# Altium schematic sheet STM32.SchDoc
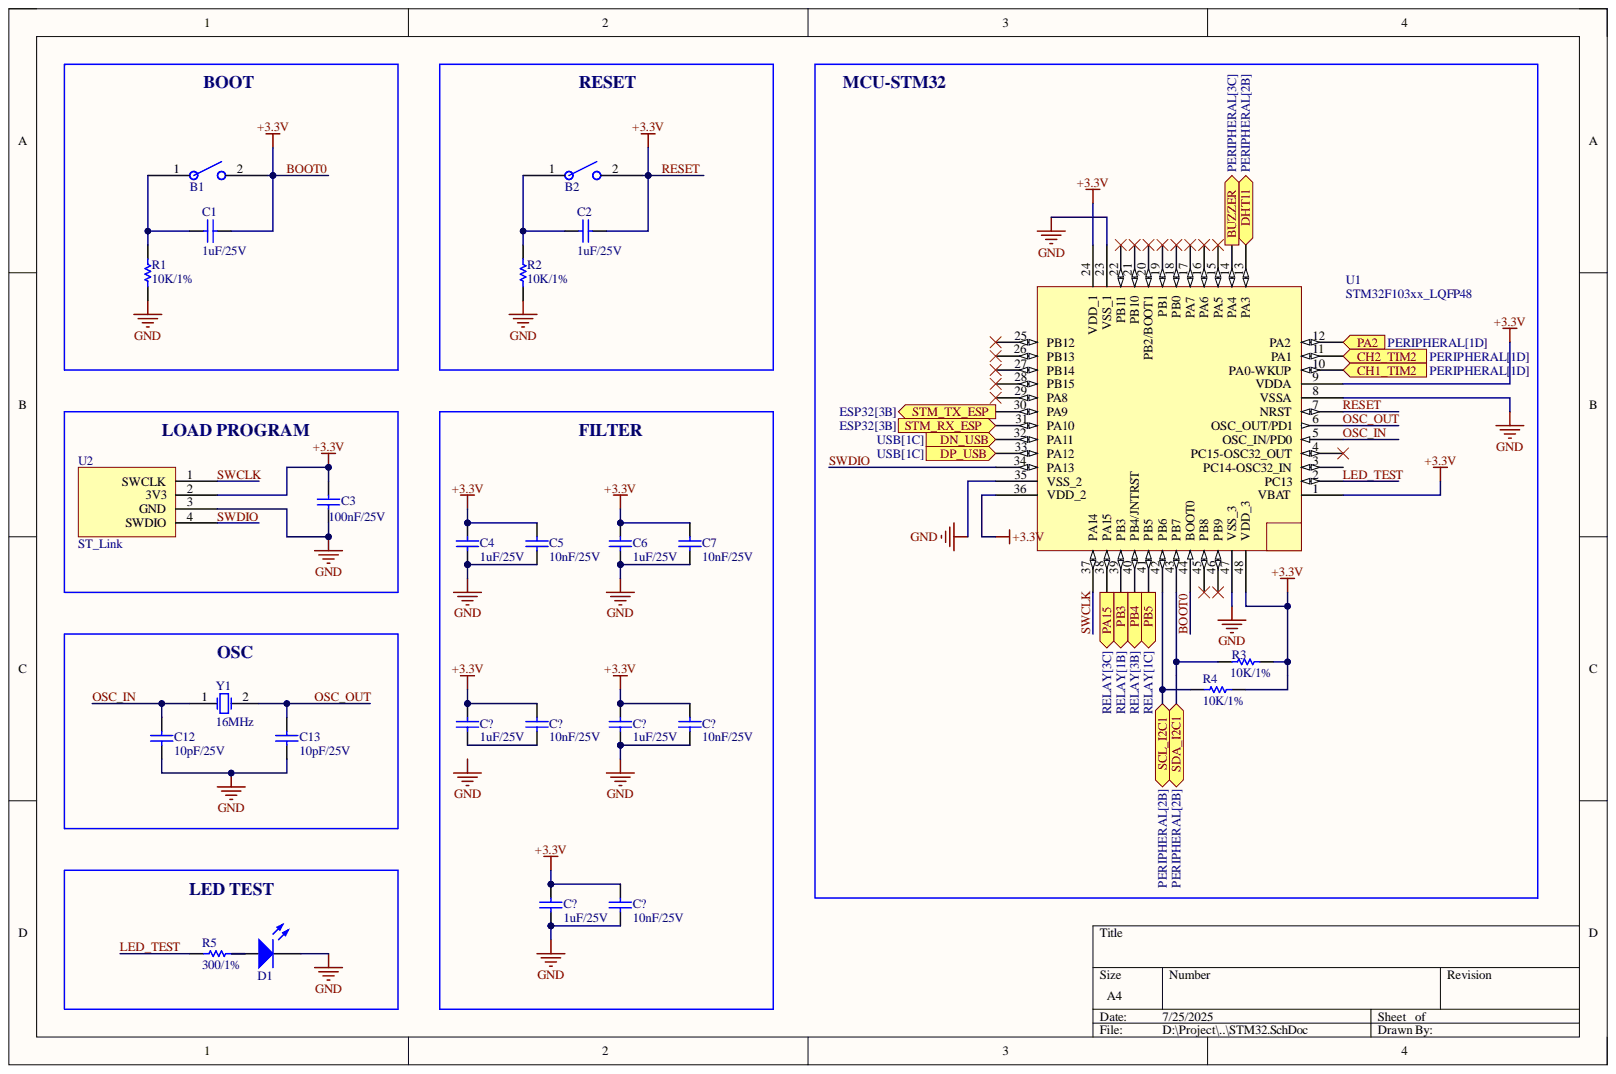
[diagram p0: STM32.SchDoc]

## Parts
B1  Button SMD 2 Pin 3x4mm  [BUTTON SMD 2 PIN]  (value not drawn)
B2  Button SMD 2 Pin 3x4mm  [BUTTON SMD 2 PIN]  (value not drawn)
C1  value 1uF/25V  [CAP-SM-0805]  (hidden comment: Cap Non-Pol SMD)
C2  value 1uF/25V  [CAP-SM-0805]  (hidden comment: Cap Non-Pol SMD)
C3  value 100nF/25V  [CAP-SM-0805]  (hidden comment: Cap Non-Pol SMD)
C4  value 1uF/25V  [CAP-SM-0805]  (hidden comment: Cap Non-Pol SMD)
C5  value 10nF/25V  [CAP-SM-0805]  (hidden comment: Cap Non-Pol SMD)
C6  value 1uF/25V  [CAP-SM-0805]  (hidden comment: Cap Non-Pol SMD)
C7  value 10nF/25V  [CAP-SM-0805]  (hidden comment: Cap Non-Pol SMD)
C12  value 10pF/25V  [CAP-SM-0805]  (hidden comment: Cap Non-Pol SMD)
C13  value 10pF/25V  [CAP-SM-0805]  (hidden comment: Cap Non-Pol SMD)
C?  value 10nF/25V  [CAP-SM-0805]  (hidden comment: Cap Non-Pol SMD)
C?  value 1uF/25V  [CAP-SM-0805]  (hidden comment: Cap Non-Pol SMD)
C?  value 10nF/25V  [CAP-SM-0805]  (hidden comment: Cap Non-Pol SMD)
C?  value 10nF/25V  [CAP-SM-0805]  (hidden comment: Cap Non-Pol SMD)
C?  value 1uF/25V  [CAP-SM-0805]  (hidden comment: Cap Non-Pol SMD)
C?  value 1uF/25V  [CAP-SM-0805]  (hidden comment: Cap Non-Pol SMD)
D1  LED SMD  [LED_0603_Blue]  (value not drawn)
R1  value 10K/1%  [RES-SM-0805]  (hidden comment: RESISTOR SMD)
R2  value 10K/1%  [RES-SM-0805]  (hidden comment: RESISTOR SMD)
R3  value 10K/1%  [RES-SM-0805]  (hidden comment: RESISTOR SMD)
R4  value 10K/1%  [RES-SM-0805]  (hidden comment: RESISTOR SMD)
R5  value 300/1%  [RES-SM-0805]  (hidden comment: RESISTOR SMD)
U1  STM32F103xx_LQFP48  [STM32_LQPF48]
U2  ST_Link  [Header 4 Pin]
Y1  16MHz  [WE-XTAL_HC49/4HSMX]

## Ports
BUZZER, CH1_TIM2, CH2_TIM2, DHT11, DN_USB, DP_USB, PA2, PA15, PB3, PB4, PB5, SCL_I2C1, SDA_I2C1, STM_RX_ESP, STM_TX_ESP

## Nets
GND: C3.1 C4.1 C5.1 C6.1 C7.1 C12.2 C13.2 C?.1 D1.2 R1.1 R2.1 U1.8(VSSA) U1.23(VSS_1) U1.35(VSS_2) U1.47(VSS_3) U2.3(GND)
BOOT0: B1.2 B2.2 C1.2 C2.2 C3.2 C4.2 C5.2 C6.2 C7.2 C?.2 R3.1 R4.1 U1.1(VBAT) U1.7(NRST) U1.9(VDDA) U1.24(VDD_1) U1.36(VDD_2) U1.44(BOOT0) U1.48(VDD_3) U2.2(3V3)
BUZZER: U1.14(PA4) ; port BUZZER
CH1_TIM2: U1.10(PA0-WKUP) ; port CH1_TIM2
CH2_TIM2: U1.11(PA1) ; port CH2_TIM2
DHT11: U1.13(PA3) ; port DHT11
DN_USB: U1.32(PA11) ; port DN_USB
DP_USB: U1.33(PA12) ; port DP_USB
LED_TEST: R5.1 U1.2(PC13)
OSC_IN: C12.1 U1.5(OSC_IN/PD0) Y1.1
OSC_OUT: C13.1 U1.6(OSC_OUT/PD1) Y1.2
PA2: U1.12(PA2) ; port PA2
PA15: U1.38(PA15) ; port PA15
PB3: U1.39(PB3) ; port PB3
PB4: U1.40(PB4/JNTRST) ; port PB4
PB5: U1.41(PB5) ; port PB5
SCL_I2C1: R4.2 U1.42(PB6) ; port SCL_I2C1
SDA_I2C1: R3.2 U1.43(PB7) ; port SDA_I2C1
STM_RX_ESP: U1.31(PA10) ; port STM_RX_ESP
STM_TX_ESP: U1.30(PA9) ; port STM_TX_ESP
SWCLK: U1.37(PA14) U2.1(SWCLK)
SWDIO: U1.34(PA13) U2.4(SWDIO)
NetB1_1: B1.1 C1.1 R1.2
NetB2_1: B2.1 C2.1 R2.2
NetD1_1: D1.1 R5.2

Not connected: U1 3(PC14-OSC32_IN) 4(PC15-OSC32_OUT) 15(PA5) 16(PA6) 17(PA7) 18(PB0) 19(PB1) 20(PB2/BOOT1) 21(PB10) 22(PB11) 25(PB12) 26(PB13) 27(PB14) 28(PB15) 29(PA8) 45(PB8) 46(PB9)

## Library data of the parts
B1 B2 = BUTTON: Component Kind: Standard; Description: Button SMD 2 Pin 3x4mm; Library Name: Libs_NGOCCAM.IntLib
C1-C7 C12 C13 C? C? C? C? C? C? = Cap Non-Pol SMD: Capacitor; Component Kind: Standard; Library Name: PIF_Library.IntLib
D1 = LED SMD: LED; Component Kind: Standard; Library Name: PIF_Library.IntLib
R1-R5 = RESISTOR SMD: Resistor; Component Kind: Standard; Library Name: PIF_Library.IntLib
Y1 = 071105166016: WE-XTAL Quartz Crystal, SMT, HC49/4HSMX, 16MHz, +/-30ppm; Manufacturer: Wurth Elektronik; Manufacturer Part Number: 830003237; Frequency Stability: +/-50ppm; Load Capacitance: 16pF; Component Kind: Standard; ComponentLink1Description: Datasheet link; ComponentLink1URL: https://katalog.we-online.com/pbs/datasheet/830003237.pdf; ComponentLink2Description: Supplier 1 URL; ComponentLink2URL: https://www.mouser.com/ProductDetail/Wurth-Elektronik/830003237?qs=2WXlatMagcFy7La1wROd1w%3D%3D; ComponentLink3Description: Supplier 2 URL; ComponentLink3URL: https://www.digikey.com/en/products/detail/w%C3%BCrth-elektronik/830003237/13979248; ComponentLink4Description: Supplier 3 URL; ComponentLink4URL: https://www.thegioiic.com/thach-anh-16mhz-2-chan-smd-hc49-s; Frequency: 16MHz; Frequency Tolerance: +/-30ppm; Library Name: Altium_XTAL.IntLib; Mounting Technology: SMT; Operating Temperature: -10 to +60degC; Size: HC49/4HSMX; Supplier 1 Name: Mouser; Supplier 1 SN: 710-830003237; Supplier 1 URL: https://www.mouser.com/ProductDetail/Wurth-Elektronik/830003237?qs=2WXlatMagcFy7La1wROd1w%3D%3D; Supplier 2 Name: Digi-Key; Supplier 2 SN: 732-830003237TR-ND; Supplier 2 URL: https://www.digikey.com/en/products/detail/w%C3%BCrth-elektronik/830003237/13979248; Supplier 3 Name: thegioiic; Supplier 3 SN: N/A; Supplier 3 URL: https://www.thegioiic.com/thach-anh-16mhz-2-chan-smd-hc49-s; Value: 16MHz
U1 = STM32F103xx_LQFP48: Component Kind: Standard; ComponentLink1Description: Manufacturer Link; ComponentLink1URL: www.st.com/stm32; ComponentLink2Description: Datasheet; ComponentLink2URL: http://www.st.com/stonline/products/literature/ds/13587.pdf; DatasheetVersion: rev6, Mar-2008; PackageDescription: 48-Pin Low-Profile Quad Flat Pack, 7 x 7 mm Body, 0.5 mm Pitch (LQFP48); PackageReference: LQFP48; PackageVersion: Mar-2008; Published: 30-May-2008; Library Name: Altium_Lib_by_KhueNguyenCreator.IntLib; Publisher: Altium Limited
U2 = ST_Link: Component Kind: Standard; Library Name: Schematic.SchLib
